# Altium schematic sheet 16_TEC.SchDoc(16_TEC)
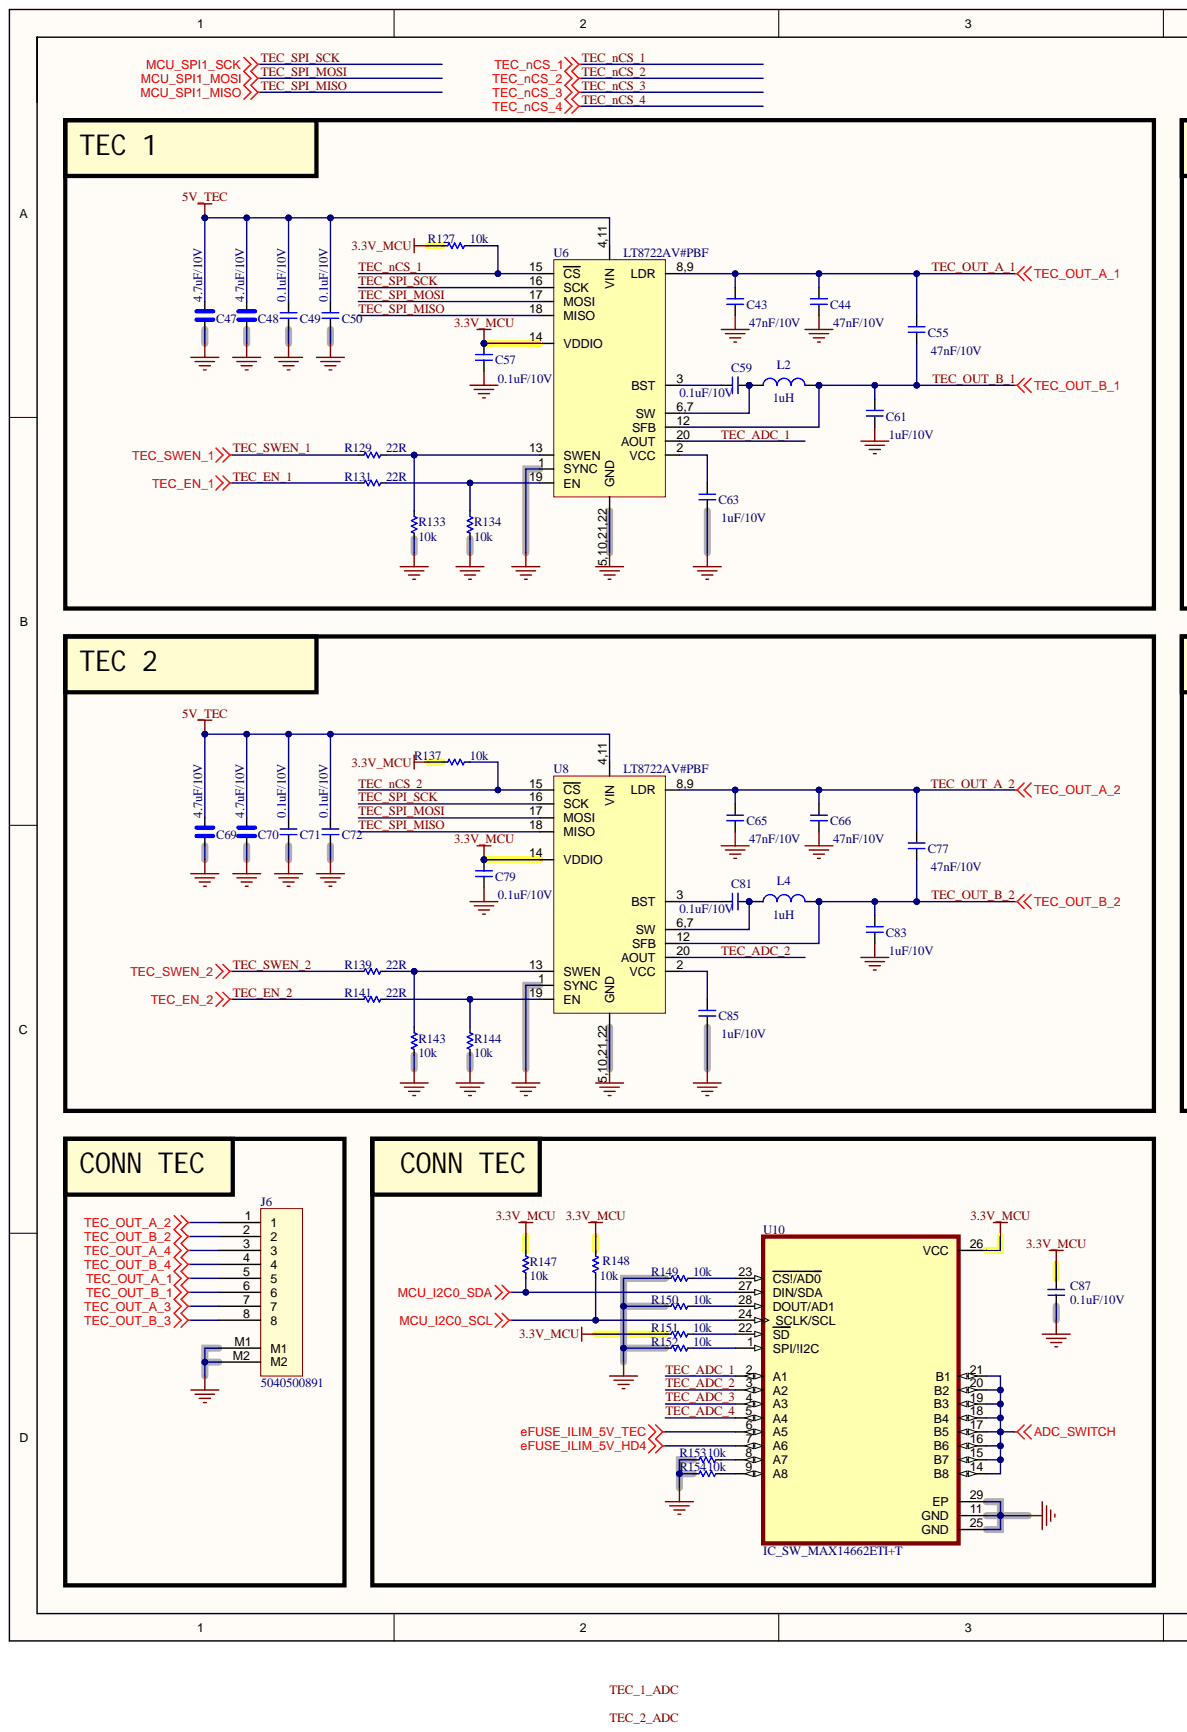
[diagram p0: 16_TEC.SchDoc, left]
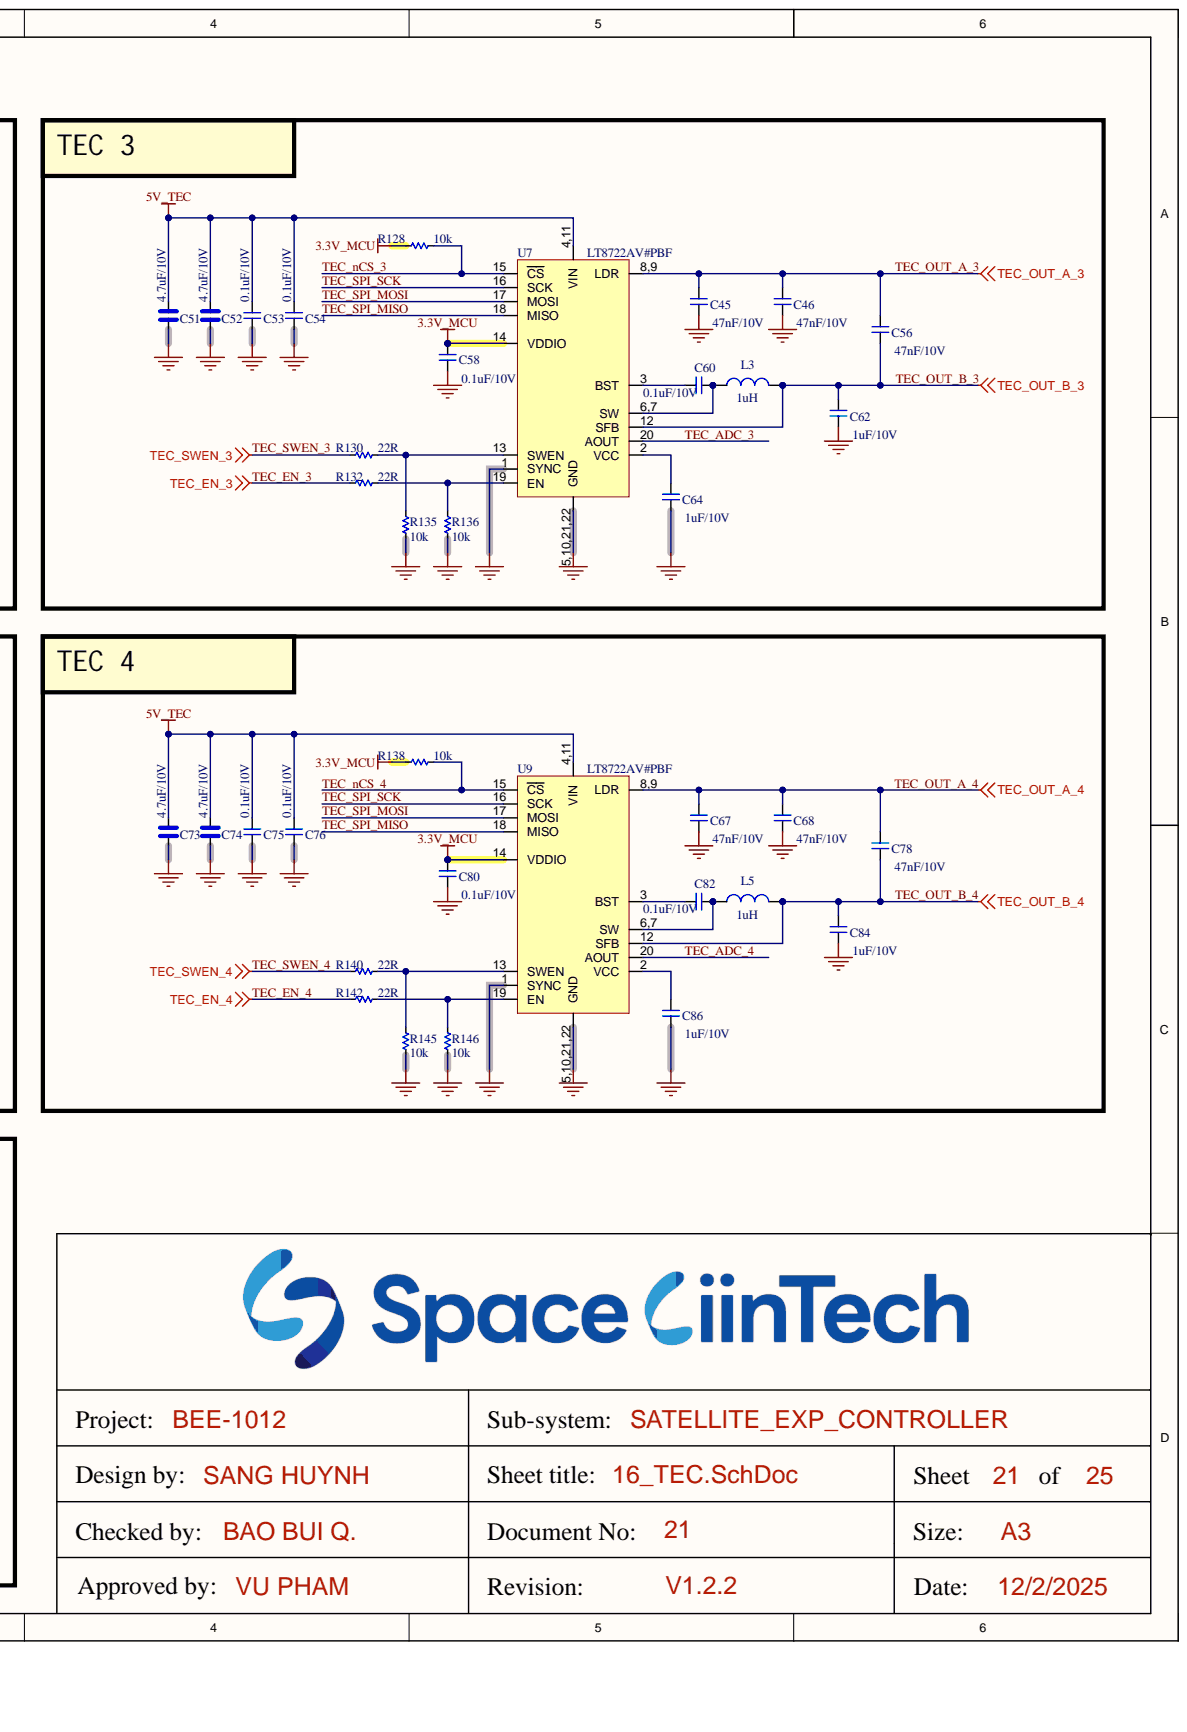
[diagram p1: 16_TEC.SchDoc, right]

## Parts
C43  47nF/10V  [CAP_C_1005X60]
C44  47nF/10V  [CAP_C_1005X60]
C45  47nF/10V  [CAP_C_1005X60]
C46  47nF/10V  [CAP_C_1005X60]
C47  4.7uF/10V  [CAP_C_1608X80]
C48  4.7uF/10V  [CAP_C_1608X80]
C49  0.1uF/10V  [CAP_C_1005X60]
C50  0.1uF/10V  [CAP_C_1005X60]
C51  4.7uF/10V  [CAP_C_1608X80]
C52  4.7uF/10V  [CAP_C_1608X80]
C53  0.1uF/10V  [CAP_C_1005X60]
C54  0.1uF/10V  [CAP_C_1005X60]
C55  47nF/10V  [CAP_C_1005X60]
C56  47nF/10V  [CAP_C_1005X60]
C57  0.1uF/10V  [CAP_C_1005X60]
C58  0.1uF/10V  [CAP_C_1005X60]
C59  0.1uF/10V  [CAP_C_1005X60]
C60  0.1uF/10V  [CAP_C_1005X60]
C61  1uF/10V  [CAP_C_1005X60]
C62  1uF/10V  [CAP_C_1005X60]
C63  1uF/10V  [CAP_C_1005X60]
C64  1uF/10V  [CAP_C_1005X60]
C65  47nF/10V  [CAP_C_1005X60]
C66  47nF/10V  [CAP_C_1005X60]
C67  47nF/10V  [CAP_C_1005X60]
C68  47nF/10V  [CAP_C_1005X60]
C69  4.7uF/10V  [CAP_C_1608X80]
C70  4.7uF/10V  [CAP_C_1608X80]
C71  0.1uF/10V  [CAP_C_1005X60]
C72  0.1uF/10V  [CAP_C_1005X60]
C73  4.7uF/10V  [CAP_C_1608X80]
C74  4.7uF/10V  [CAP_C_1608X80]
C75  0.1uF/10V  [CAP_C_1005X60]
C76  0.1uF/10V  [CAP_C_1005X60]
C77  47nF/10V  [CAP_C_1005X60]
C78  47nF/10V  [CAP_C_1005X60]
C79  0.1uF/10V  [CAP_C_1005X60]
C80  0.1uF/10V  [CAP_C_1005X60]
C81  0.1uF/10V  [CAP_C_1005X60]
C82  0.1uF/10V  [CAP_C_1005X60]
C83  1uF/10V  [CAP_C_1005X60]
C84  1uF/10V  [CAP_C_1005X60]
C85  1uF/10V  [CAP_C_1005X60]
C86  1uF/10V  [CAP_C_1005X60]
C87  0.1uF/10V  [CAP_C_1005X60]
J6  5040500891  [MOLEX_5040500891]
L2  1uH  [L-SMD-M-3.5x3.5x2mm]
L3  1uH  [L-SMD-M-3.5x3.5x2mm]
L4  1uH  [L-SMD-M-3.5x3.5x2mm]
L5  1uH  [L-SMD-M-3.5x3.5x2mm]
R127  10k  [RES_C_1005X40]
R128  10k  [RES_C_1005X40]
R129  22R  [RES_C_1005X40]
R130  22R  [RES_C_1005X40]
R131  22R  [RES_C_1005X40]
R132  22R  [RES_C_1005X40]
R133  10k  [RES_C_1005X40]
R134  10k  [RES_C_1005X40]
R135  10k  [RES_C_1005X40]
R136  10k  [RES_C_1005X40]
R137  10k  [RES_C_1005X40]
R138  10k  [RES_C_1005X40]
R139  22R  [RES_C_1005X40]
R140  22R  [RES_C_1005X40]
R141  22R  [RES_C_1005X40]
R142  22R  [RES_C_1005X40]
R143  10k  [RES_C_1005X40]
R144  10k  [RES_C_1005X40]
R145  10k  [RES_C_1005X40]
R146  10k  [RES_C_1005X40]
R147  10k  [RES_C_1005X40]
R148  10k  [RES_C_1005X40]
R149  10k  [RES_C_1005X40]
R150  10k  [RES_C_1005X40]
R151  10k  [RES_C_1005X40]
R152  10k  [RES_C_1005X40]
R153  10k  [RES_C_1005X40]  (value not drawn)
R154  10k  [RES_C_1005X40]  (value not drawn)
U6  LT8722AV#PBF  [QFN18]
U7  LT8722AV#PBF  [QFN18]
U8  LT8722AV#PBF  [QFN18]
U9  LT8722AV#PBF  [QFN18]
U10  IC_SW_MAX14662ETI+T  [QFN40P400X400X80-29N]

## Nets
3.3V_MCU: C57.2 C58.2 C79.2 C80.2 C87.2 R127.1 R128.1 R137.1 R138.1 R147.2 R148.2 R151.1 U6.14(VDDIO) U7.14(VDDIO) U8.14(VDDIO) U9.14(VDDIO) U10.26(VCC)
5V_TEC: C47.2 C48.2 C49.2 C50.2 C51.2 C52.2 C53.2 C54.2 C69.2 C70.2 C71.2 C72.2 C73.2 C74.2 C75.2 C76.2 U6.4,11(VIN) U7.4,11(VIN) U8.4,11(VIN) U9.4,11(VIN)
ADC_SWITCH: U10.14(B8) U10.15(B7) U10.16(B6) U10.17(B5) U10.18(B4) U10.19(B3) U10.20(B2) U10.21(B1)
eFUSE_ILIM_5V_HD4: U10.7(A6)
eFUSE_ILIM_5V_TEC: U10.6(A5)
GND: C43.1 C44.1 C45.1 C46.1 C47.1 C48.1 C49.1 C50.1 C51.1 C52.1 C53.1 C54.1 C57.1 C58.1 C61.1 C62.1 C63.1 C64.1 C65.1 C66.1 C67.1 C68.1 C69.1 C70.1 C71.1 C72.1 C73.1 C74.1 C75.1 C76.1 C79.1 C80.1 C83.1 C84.1 C85.1 C86.1 C87.1 J6.M1 J6.M2 R133.2 R134.2 R135.2 R136.2 R143.2 R144.2 R145.2 R146.2 R149.1 R150.1 R152.1 R153.1 R154.1 U6.1(SYNC) U6.5,10,21,22(GND) U7.1(SYNC) U7.5,10,21,22(GND) U8.1(SYNC) U8.5,10,21,22(GND) U9.1(SYNC) U9.5,10,21,22(GND) U10.11(GND) U10.25(GND) U10.29(EP)
MCU_I2C0_SCL: R148.1 U10.24(SCLK/SCL)
MCU_I2C0_SDA: R147.1 U10.27(DIN/SDA)
TEC_ADC_1: U6.20(AOUT) U10.2(A1)
TEC_ADC_2: U8.20(AOUT) U10.3(A2)
TEC_ADC_3: U7.20(AOUT) U10.4(A3)
TEC_ADC_4: U9.20(AOUT) U10.5(A4)
TEC_EN_1: R131.2
TEC_EN_2: R141.2
TEC_EN_3: R132.2
TEC_EN_4: R142.2
TEC_nCS_1: R127.2 U6.15(CS)
TEC_nCS_2: R137.2 U8.15(CS)
TEC_nCS_3: R128.2 U7.15(CS)
TEC_nCS_4: R138.2 U9.15(CS)
TEC_OUT_A_1: C43.2 C44.2 C55.2 J6.5 U6.8,9(LDR)
TEC_OUT_A_2: C65.2 C66.2 C77.2 J6.1 U8.8,9(LDR)
TEC_OUT_A_3: C45.2 C46.2 C56.2 J6.7 U7.8,9(LDR)
TEC_OUT_A_4: C67.2 C68.2 C78.2 J6.3 U9.8,9(LDR)
TEC_OUT_B_1: C55.1 C61.2 J6.6 L2.2 U6.12(SFB)
TEC_OUT_B_2: C77.1 C83.2 J6.2 L4.2 U8.12(SFB)
TEC_OUT_B_3: C56.1 C62.2 J6.8 L3.2 U7.12(SFB)
TEC_OUT_B_4: C78.1 C84.2 J6.4 L5.2 U9.12(SFB)
TEC_SPI_MISO: U6.18(MISO) U7.18(MISO) U8.18(MISO) U9.18(MISO)
TEC_SPI_MOSI: U6.17(MOSI) U7.17(MOSI) U8.17(MOSI) U9.17(MOSI)
TEC_SPI_SCK: U6.16(SCK) U7.16(SCK) U8.16(SCK) U9.16(SCK)
TEC_SWEN_1: R129.1
TEC_SWEN_2: R139.1
TEC_SWEN_3: R130.1
TEC_SWEN_4: R140.1
NetC59_1: C59.1 L2.1 U6.6,7(SW)
NetC59_2: C59.2 U6.3(BST)
NetC60_1: C60.1 L3.1 U7.6,7(SW)
NetC60_2: C60.2 U7.3(BST)
NetC63_2: C63.2 U6.2(VCC)
NetC64_2: C64.2 U7.2(VCC)
NetC81_1: C81.1 L4.1 U8.6,7(SW)
NetC81_2: C81.2 U8.3(BST)
NetC82_1: C82.1 L5.1 U9.6,7(SW)
NetC82_2: C82.2 U9.3(BST)
NetC85_2: C85.2 U8.2(VCC)
NetC86_2: C86.2 U9.2(VCC)
NetR129_2: R129.2 R133.1 U6.13(SWEN)
NetR130_2: R130.2 R135.1 U7.13(SWEN)
NetR131_1: R131.1 R134.1 U6.19(EN)
NetR132_1: R132.1 R136.1 U7.19(EN)
NetR139_2: R139.2 R143.1 U8.13(SWEN)
NetR140_2: R140.2 R145.1 U9.13(SWEN)
NetR141_1: R141.1 R144.1 U8.19(EN)
NetR142_1: R142.1 R146.1 U9.19(EN)
NetR149_2: R149.2 U10.23(CS!/AD0)
NetR150_2: R150.2 U10.28(DOUT/AD1)
NetR151_2: R151.2 U10.22(SD)
NetR152_2: R152.2 U10.1(SPI/!I2C)
NetR153_2: R153.2 U10.8(A7)
NetR154_2: R154.2 U10.9(A8)
TEC_1_ADC:
TEC_2_ADC:
TEC_3_ADC:
TEC_4_ADC:

## Library data of the parts
C43-C46 C49 C50 C53-C68 C71 C72 C75-C87 = _CAP_C_2: CAP CHIP; Component Kind: Standard; Library Name: LABLIB.SchLib
C47 C48 C51 C52 C69 C70 C73 C74 = _CAP_C_High_2: CAP CHIP High Value; Component Kind: Standard; Library Name: LABLIB.SchLib
J6 = Header 8x1_2M: Component Kind: Standard; Library Name: LABLIB.SchLib
L2-L5 = L-SMD-1: Manufacturer: Wurth Elektronik; partNo: 78477010; Inductance: 1.0 μH; Component Kind: Standard; ComponentLink1Description: Manufacturer; ComponentLink1URL: http://www.we-online.de; ComponentLink2Description: Datasheet; ComponentLink2URL: http://katalog.we-online.de/pbs/datasheet/78477010.pdf; Created Date: Nov.2015; Created by: Baojun Chen; Library Name: EXP_HW_V3.SchLib
R127-R154 = _RES_B_2: RES; Component Kind: Standard; Library Name: LABLIB.SchLib
U6-U9 = LT8722: Component Kind: Standard; Library Name: EXP_HW_V3.SchLib
U10 = IC_SW_MAX14662ETI+T: 8 Circuit IC Switch 1:1 1Ohm 28-TQFN _4x4_; MF: Maxim Integrated; Availability: Unavailable; Component Kind: Standard; MP: MAX14662ETI+T; Package: TQFN-28 Maxim; Price: None; SnapEDA_Link: https://www.snapeda.com/parts/MAX14662ETI%2BT/Maxim%20Integrated/view-part/546683/?ref=snap; Library Name: LABLIB.SchLib
